# Altium schematic sheet Serial Monitor Data Processor Board Schematic.SchDoc("Serial Monitor Data Processor Board Schematic")
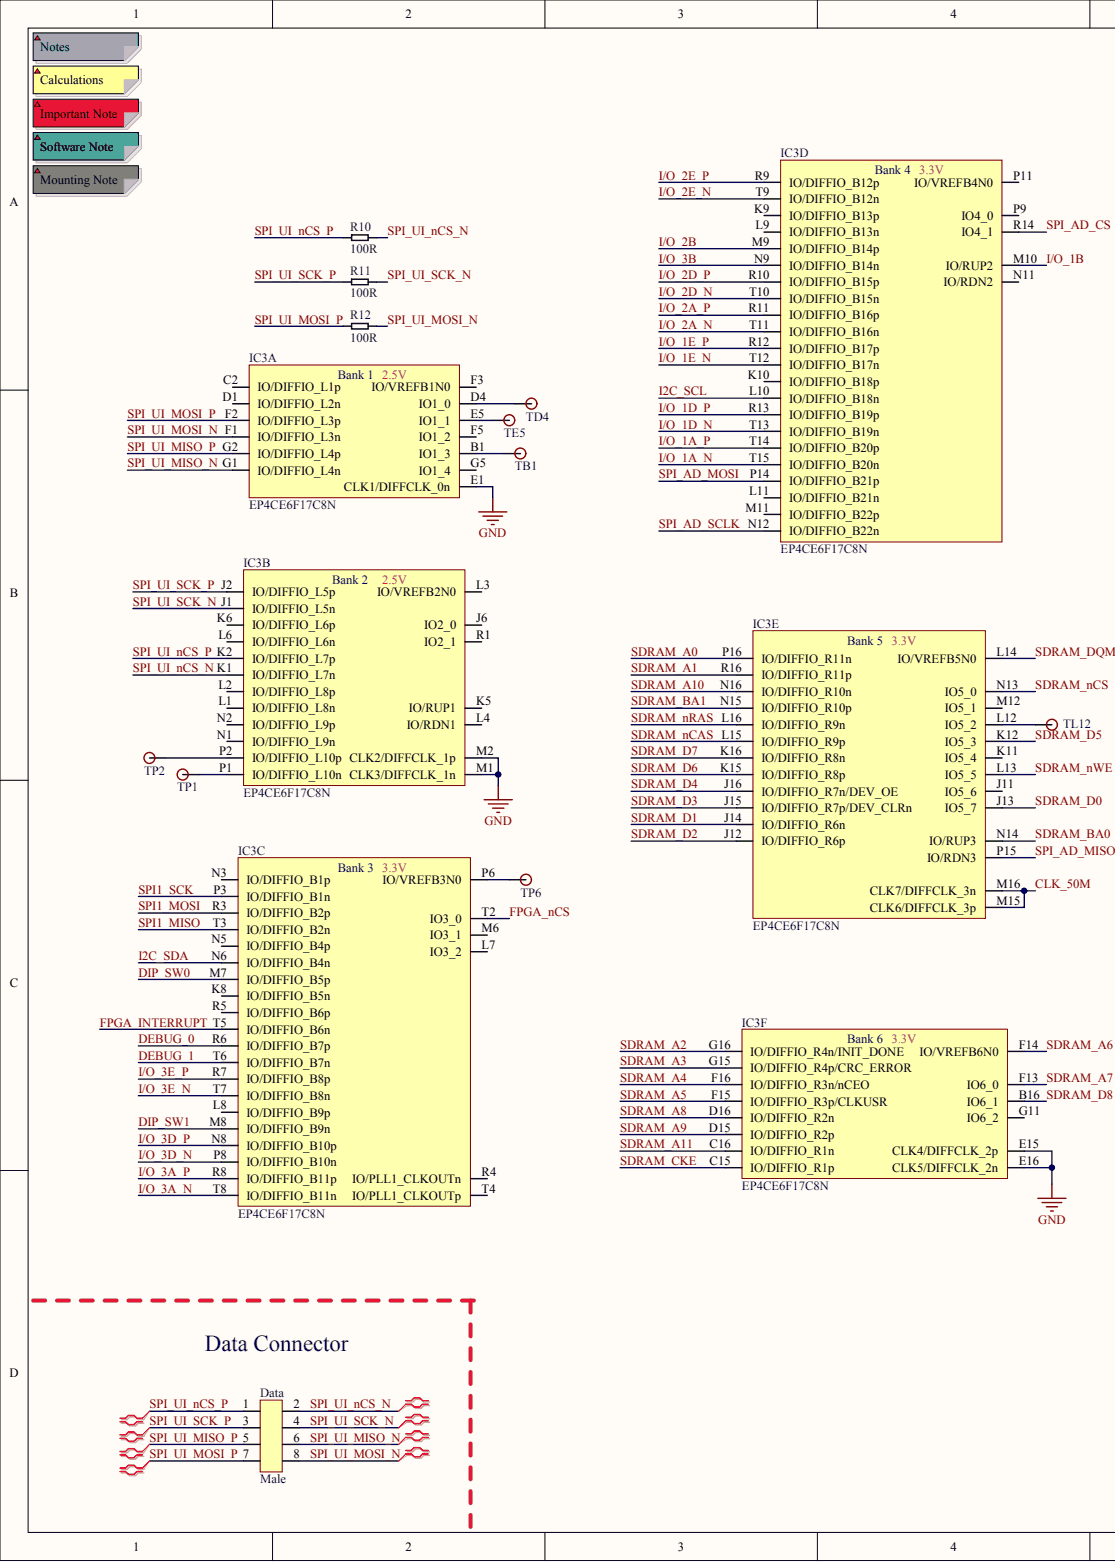
[diagram p0: Serial Monitor Data Processor Board Schematic.SchDoc, left]
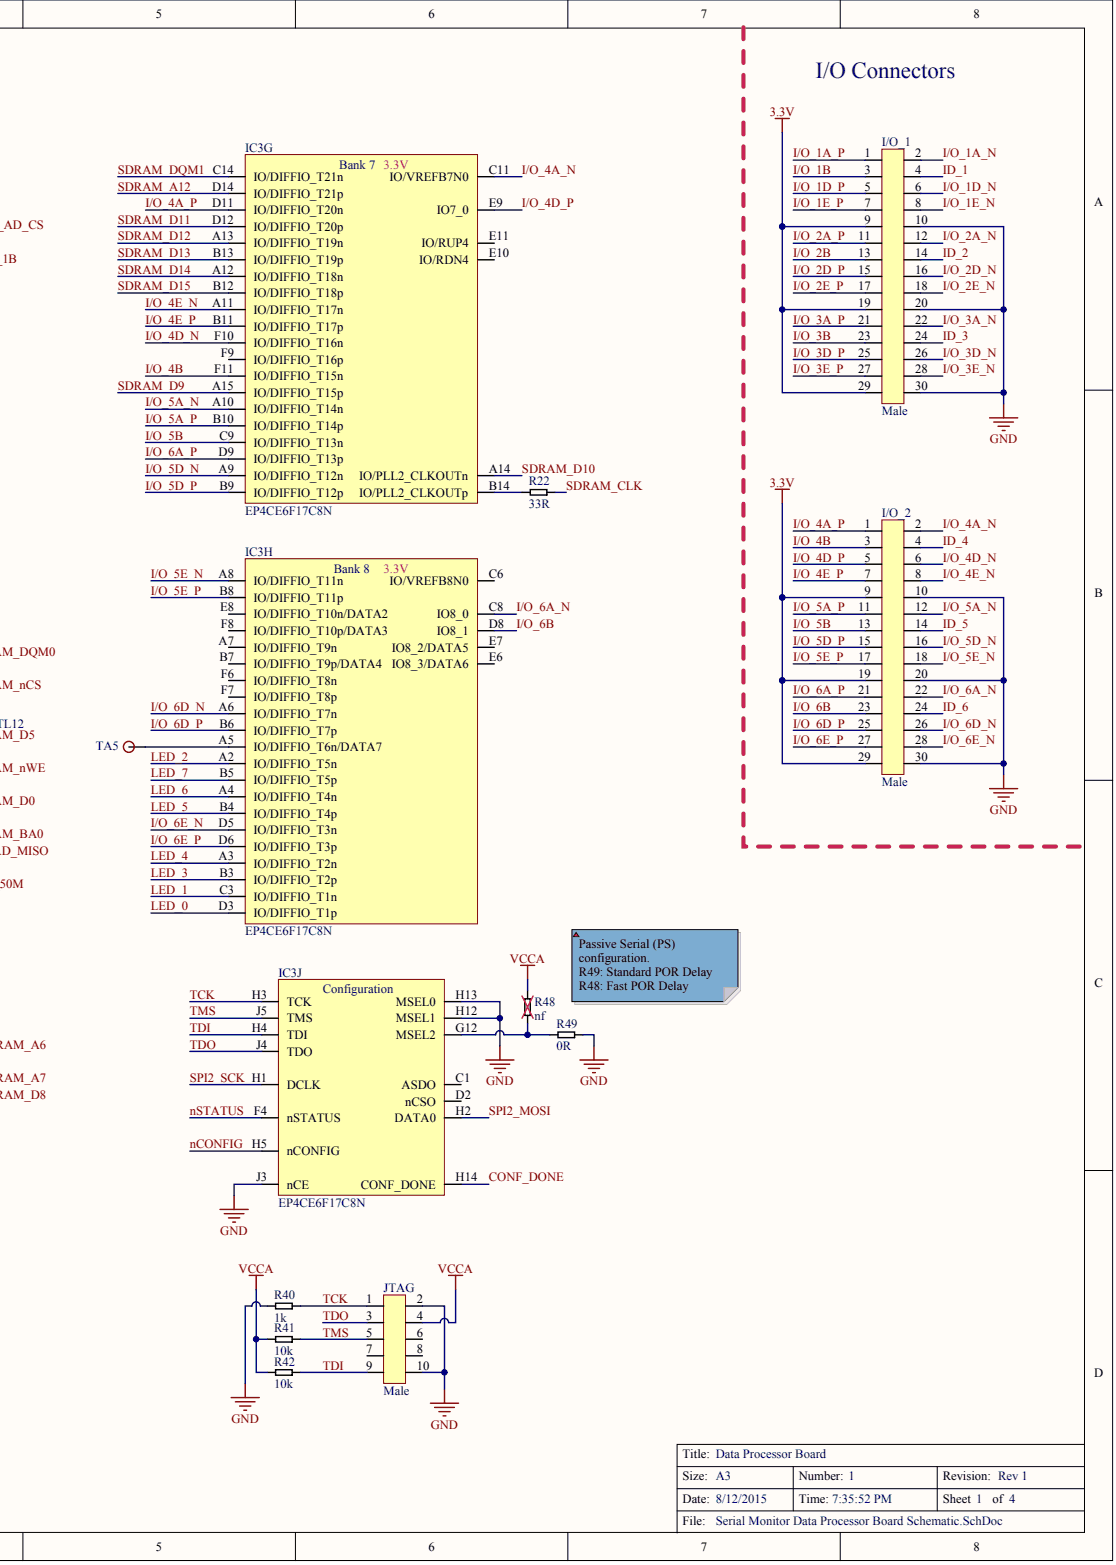
[diagram p1: Serial Monitor Data Processor Board Schematic.SchDoc, right]

## Parts
Data  value Male  (hidden comment: Connector 2x4)
I/O_1  value Male  (hidden comment: Connector 2x15)
I/O_2  value Male  (hidden comment: Connector 2x15)
IC3  EP4CE6F17C8N  [FPGA-256]  (parts A,B,C,D,E,F,G,H,J)
JTAG  value Male  (hidden comment: Connector 2x5)
R10  value 100R  [0603]  (hidden comment: Res_0603_100R)
R11  value 100R  [0603]  (hidden comment: Res_0603_100R)
R12  value 100R  [0603]  (hidden comment: Res_0603_100R)
R22  value 33R  [0603]  (hidden comment: Res_0603_33R)
R40  value 1k  [0603]  (hidden comment: Res_0603_1k)
R41  value 10k  [0603]  (hidden comment: Res_0603_10k)
R42  value 10k  [0603]  (hidden comment: Res_0603_10k)
R48  value nf  [0603]  (hidden comment: Res_0603_nf)
R49  value 0R  [0603]  (hidden comment: Res_0603_0R)
TA5  Testpad  (value not drawn)
TB1  Testpad  (value not drawn)
TD4  Testpad  (value not drawn)
TE5  Testpad  (value not drawn)
TL12  Testpad  (value not drawn)
TP1  Testpad  (value not drawn)
TP2  Testpad  (value not drawn)
TP6  Testpad  (value not drawn)

## Nets
3.3V: I/O_1.9 I/O_1.19 I/O_1.29 I/O_2.9 I/O_2.19 I/O_2.29
GND: I/O_1.10 I/O_1.20 I/O_1.30 I/O_2.10 I/O_2.20 I/O_2.30 IC3.E1(CLK1/DIFFCLK_0n) IC3.E15(CLK4/DIFFCLK_2p) IC3.E16(CLK5/DIFFCLK_2n) IC3.H12(MSEL1) IC3.H13(MSEL0) IC3.J3(nCE) IC3.M1(CLK3/DIFFCLK_1n) IC3.M2(CLK2/DIFFCLK_1p) JTAG.2 JTAG.10 R40.1 R49.2
VCCA: JTAG.4 R41.1 R42.1 R48.1
CLK_50M: IC3.M15(CLK6/DIFFCLK_3p) IC3.M16(CLK7/DIFFCLK_3n)
CONF_DONE: IC3.H14(CONF_DONE)
DEBUG_0: IC3.R6(IO/DIFFIO_B7p)
DEBUG_1: IC3.T6(IO/DIFFIO_B7n)
DIP_SW0: IC3.M7(IO/DIFFIO_B5p)
DIP_SW1: IC3.M8(IO/DIFFIO_B9n)
FPGA_INTERRUPT: IC3.T5(IO/DIFFIO_B6n)
FPGA_NCS: IC3.T2(IO3_0)
I2C_SCL: IC3.L10(IO/DIFFIO_B18n)
I2C_SDA: IC3.N6(IO/DIFFIO_B4n)
I/O_1A_N: I/O_1.2 IC3.T15(IO/DIFFIO_B20n)
I/O_1A_P: I/O_1.1 IC3.T14(IO/DIFFIO_B20p)
I/O_1B: I/O_1.3 IC3.M10(IO/RUP2)
I/O_1D_N: I/O_1.6 IC3.T13(IO/DIFFIO_B19n)
I/O_1D_P: I/O_1.5 IC3.R13(IO/DIFFIO_B19p)
I/O_1E_N: I/O_1.8 IC3.T12(IO/DIFFIO_B17n)
I/O_1E_P: I/O_1.7 IC3.R12(IO/DIFFIO_B17p)
I/O_2A_N: I/O_1.12 IC3.T11(IO/DIFFIO_B16n)
I/O_2A_P: I/O_1.11 IC3.R11(IO/DIFFIO_B16p)
I/O_2B: I/O_1.13 IC3.M9(IO/DIFFIO_B14p)
I/O_2D_N: I/O_1.16 IC3.T10(IO/DIFFIO_B15n)
I/O_2D_P: I/O_1.15 IC3.R10(IO/DIFFIO_B15p)
I/O_2E_N: I/O_1.18 IC3.T9(IO/DIFFIO_B12n)
I/O_2E_P: I/O_1.17 IC3.R9(IO/DIFFIO_B12p)
I/O_3A_N: I/O_1.22 IC3.T8(IO/DIFFIO_B11n)
I/O_3A_P: I/O_1.21 IC3.R8(IO/DIFFIO_B11p)
I/O_3B: I/O_1.23 IC3.N9(IO/DIFFIO_B14n)
I/O_3D_N: I/O_1.26 IC3.P8(IO/DIFFIO_B10n)
I/O_3D_P: I/O_1.25 IC3.N8(IO/DIFFIO_B10p)
I/O_3E_N: I/O_1.28 IC3.T7(IO/DIFFIO_B8n)
I/O_3E_P: I/O_1.27 IC3.R7(IO/DIFFIO_B8p)
I/O_4A_N: I/O_2.2 IC3.C11(IO/VREFB7N0)
I/O_4A_P: I/O_2.1 IC3.D11(IO/DIFFIO_T20n)
I/O_4B: I/O_2.3 IC3.F11(IO/DIFFIO_T15n)
I/O_4D_N: I/O_2.6 IC3.F10(IO/DIFFIO_T16n)
I/O_4D_P: I/O_2.5 IC3.E9(IO7_0)
I/O_4E_N: I/O_2.8 IC3.A11(IO/DIFFIO_T17n)
I/O_4E_P: I/O_2.7 IC3.B11(IO/DIFFIO_T17p)
I/O_5A_N: I/O_2.12 IC3.A10(IO/DIFFIO_T14n)
I/O_5A_P: I/O_2.11 IC3.B10(IO/DIFFIO_T14p)
I/O_5B: I/O_2.13 IC3.C9(IO/DIFFIO_T13n)
I/O_5D_N: I/O_2.16 IC3.A9(IO/DIFFIO_T12n)
I/O_5D_P: I/O_2.15 IC3.B9(IO/DIFFIO_T12p)
I/O_5E_N: I/O_2.18 IC3.A8(IO/DIFFIO_T11n)
I/O_5E_P: I/O_2.17 IC3.B8(IO/DIFFIO_T11p)
I/O_6A_N: I/O_2.22 IC3.C8(IO8_0)
I/O_6A_P: I/O_2.21 IC3.D9(IO/DIFFIO_T13p)
I/O_6B: I/O_2.23 IC3.D8(IO8_1)
I/O_6D_N: I/O_2.26 IC3.A6(IO/DIFFIO_T7n)
I/O_6D_P: I/O_2.25 IC3.B6(IO/DIFFIO_T7p)
I/O_6E_N: I/O_2.28 IC3.D5(IO/DIFFIO_T3n)
I/O_6E_P: I/O_2.27 IC3.D6(IO/DIFFIO_T3p)
ID_1: I/O_1.4
ID_2: I/O_1.14
ID_3: I/O_1.24
ID_4: I/O_2.4
ID_5: I/O_2.14
ID_6: I/O_2.24
LED_0: IC3.D3(IO/DIFFIO_T1p)
LED_1: IC3.C3(IO/DIFFIO_T1n)
LED_2: IC3.A2(IO/DIFFIO_T5n)
LED_3: IC3.B3(IO/DIFFIO_T2p)
LED_4: IC3.A3(IO/DIFFIO_T2n)
LED_5: IC3.B4(IO/DIFFIO_T4p)
LED_6: IC3.A4(IO/DIFFIO_T4n)
LED_7: IC3.B5(IO/DIFFIO_T5p)
NCONFIG: IC3.H5(nCONFIG)
NSTATUS: IC3.F4(nSTATUS)
SDRAM_A0: IC3.P16(IO/DIFFIO_R11n)
SDRAM_A1: IC3.R16(IO/DIFFIO_R11p)
SDRAM_A2: IC3.G16(IO/DIFFIO_R4n/INIT_DONE)
SDRAM_A3: IC3.G15(IO/DIFFIO_R4p/CRC_ERROR)
SDRAM_A4: IC3.F16(IO/DIFFIO_R3n/nCEO)
SDRAM_A5: IC3.F15(IO/DIFFIO_R3p/CLKUSR)
SDRAM_A6: IC3.F14(IO/VREFB6N0)
SDRAM_A7: IC3.F13(IO6_0)
SDRAM_A8: IC3.D16(IO/DIFFIO_R2n)
SDRAM_A9: IC3.D15(IO/DIFFIO_R2p)
SDRAM_A10: IC3.N16(IO/DIFFIO_R10n)
SDRAM_A11: IC3.C16(IO/DIFFIO_R1n)
SDRAM_A12: IC3.D14(IO/DIFFIO_T21p)
SDRAM_BA0: IC3.N14(IO/RUP3)
SDRAM_BA1: IC3.N15(IO/DIFFIO_R10p)
SDRAM_CKE: IC3.C15(IO/DIFFIO_R1p)
SDRAM_CLK: R22.2
SDRAM_D0: IC3.J13(IO5_7)
SDRAM_D1: IC3.J14(IO/DIFFIO_R6n)
SDRAM_D2: IC3.J12(IO/DIFFIO_R6p)
SDRAM_D3: IC3.J15(IO/DIFFIO_R7p/DEV_CLRn)
SDRAM_D4: IC3.J16(IO/DIFFIO_R7n/DEV_OE)
SDRAM_D5: IC3.K12(IO5_3)
SDRAM_D6: IC3.K15(IO/DIFFIO_R8p)
SDRAM_D7: IC3.K16(IO/DIFFIO_R8n)
SDRAM_D8: IC3.B16(IO6_1)
SDRAM_D9: IC3.A15(IO/DIFFIO_T15p)
SDRAM_D10: IC3.A14(IO/PLL2_CLKOUTn)
SDRAM_D11: IC3.D12(IO/DIFFIO_T20p)
SDRAM_D12: IC3.A13(IO/DIFFIO_T19n)
SDRAM_D13: IC3.B13(IO/DIFFIO_T19p)
SDRAM_D14: IC3.A12(IO/DIFFIO_T18n)
SDRAM_D15: IC3.B12(IO/DIFFIO_T18p)
SDRAM_DQM0: IC3.L14(IO/VREFB5N0)
SDRAM_DQM1: IC3.C14(IO/DIFFIO_T21n)
SDRAM_NCAS: IC3.L15(IO/DIFFIO_R9p)
SDRAM_NCS: IC3.N13(IO5_0)
SDRAM_NRAS: IC3.L16(IO/DIFFIO_R9n)
SDRAM_NWE: IC3.L13(IO5_5)
SPI1_MISO: IC3.T3(IO/DIFFIO_B2n)
SPI1_MOSI: IC3.R3(IO/DIFFIO_B2p)
SPI1_SCK: IC3.P3(IO/DIFFIO_B1n)
SPI2_MOSI: IC3.H2(DATA0)
SPI2_SCK: IC3.H1(DCLK)
SPI_AD_CS: IC3.R14(IO4_1)
SPI_AD_MISO: IC3.P15(IO/RDN3)
SPI_AD_MOSI: IC3.P14(IO/DIFFIO_B21p)
SPI_AD_SCLK: IC3.N12(IO/DIFFIO_B22n)
SPI_UI_MISO_N: Data.6 IC3.G1(IO/DIFFIO_L4n)
SPI_UI_MISO_P: Data.5 IC3.G2(IO/DIFFIO_L4p)
SPI_UI_MOSI_N: Data.8 IC3.F1(IO/DIFFIO_L3n) R12.1
SPI_UI_MOSI_P: Data.7 IC3.F2(IO/DIFFIO_L3p) R12.2
SPI_UI_NCS_N: Data.2 IC3.K1(IO/DIFFIO_L7n) R10.1
SPI_UI_NCS_P: Data.1 IC3.K2(IO/DIFFIO_L7p) R10.2
SPI_UI_SCK_N: Data.4 IC3.J1(IO/DIFFIO_L5n) R11.1
SPI_UI_SCK_P: Data.3 IC3.J2(IO/DIFFIO_L5p) R11.2
TCK: IC3.H3(TCK) JTAG.1 R40.2
TDI: IC3.H4(TDI) JTAG.9 R42.2
TDO: IC3.J4(TDO) JTAG.3
TMS: IC3.J5(TMS) JTAG.5 R41.2
NetIC3_A5: IC3.A5(IO/DIFFIO_T6n/DATA7) TA5.1
NetIC3_B1: IC3.B1(IO1_3) TB1.1
NetIC3_B14: IC3.B14(IO/PLL2_CLKOUTp) R22.1
NetIC3_D4: IC3.D4(IO1_0) TD4.1
NetIC3_E5: IC3.E5(IO1_1) TE5.1
NetIC3_G12: IC3.G12(MSEL2) R48.2 R49.1
NetIC3_L12: IC3.L12(IO5_2) TL12.1
NetIC3_P1: IC3.P1(IO/DIFFIO_L10n) TP1.1
NetIC3_P2: IC3.P2(IO/DIFFIO_L10p) TP2.1
NetIC3_P6: IC3.P6(IO/VREFB3N0) TP6.1

Not connected: IC3 A7(IO/DIFFIO_T9n) B7(IO/DIFFIO_T9p/DATA4) C1(ASDO) C2(IO/DIFFIO_L1p) C6(IO/VREFB8N0) D1(IO/DIFFIO_L2n) D2(nCSO) E6(IO8_3/DATA6) E7(IO8_2/DATA5) E8(IO/DIFFIO_T10n/DATA2) E10(IO/RDN4) E11(IO/RUP4) F3(IO/VREFB1N0) F5(IO1_2) F6(IO/DIFFIO_T8n) F7(IO/DIFFIO_T8p) F8(IO/DIFFIO_T10p/DATA3) F9(IO/DIFFIO_T16p) G5(IO1_4) G11(IO6_2) J6(IO2_0) J11(IO5_6) K5(IO/RUP1) K6(IO/DIFFIO_L6p) K8(IO/DIFFIO_B5n) K9(IO/DIFFIO_B13p) K10(IO/DIFFIO_B18p) K11(IO5_4) L1(IO/DIFFIO_L8n) L2(IO/DIFFIO_L8p) L3(IO/VREFB2N0) L4(IO/RDN1) L6(IO/DIFFIO_L6n) L7(IO3_2) L8(IO/DIFFIO_B9p) L9(IO/DIFFIO_B13n) L11(IO/DIFFIO_B21n) M6(IO3_1) M11(IO/DIFFIO_B22p) M12(IO5_1) N1(IO/DIFFIO_L9n) N2(IO/DIFFIO_L9p) N3(IO/DIFFIO_B1p) N5(IO/DIFFIO_B4p) N11(IO/RDN2) P9(IO4_0) P11(IO/VREFB4N0) R1(IO2_1) R4(IO/PLL1_CLKOUTn) R5(IO/DIFFIO_B6p) T4(IO/PLL1_CLKOUTp); JTAG 6 7 8

## Library data of the parts
IC3 = (no symbol name): Manufacturer: Altera; RS: 830-3530; Part No.: EP4CE6F17C8N; Mouser: 989-EP4CE6F17C8N; DigiKey: 544-2828-ND; Price SEK (No VAT): 145; Farnell: 2100853; Value: EP4CE6F17C8N
R10-R12 = (no symbol name): Part No.: CRCW0603100RFKEA; Manufacturer: Vishayp; Price SEK (No VAT): 0,1; Mouser: 71-CRCW0603-100-E3; DigiKey: 541-100HCT-ND
R22 = (no symbol name): Part No.: CRCW060333R0FKEA; Manufacturer: Vishay; Price SEK (No VAT): 0,1; DigiKey: 541-33.0HCT-ND; Mouser: 71-CRCW0603-33-E3
R40 = (no symbol name): Part No.: CRCW06031K00FKEA; Manufacturer: Vishay; Price SEK (No VAT): 0,1; Mouser: 71-CRCW0603-1.0K-E3; DigiKey: 541-1.00KHCT-ND
R41 R42 = (no symbol name): Part No.: CRCW060310K0FKEA; Manufacturer: Vishay; Price SEK (No VAT): 0,1; Mouser: 71-CRCW0603-10K-E3; DigiKey: 541-10.0KHCT-ND
R49 = (no symbol name): Part No.: CRCW06030000Z0EA; Manufacturer: Vishay; Price SEK (No VAT): 0,1; DigiKey: 541-0.0GCT-ND; Mouser: 71-CRCW0603-0-E3
Data I/O_1 I/O_2 JTAG R48 TA5 TB1 TD4 TE5 TL12 TP1 TP2 TP6 = (no symbol name)
  R48: Price SEK (No VAT): 0
